# Altium schematic sheet 01_Connector.SchDoc
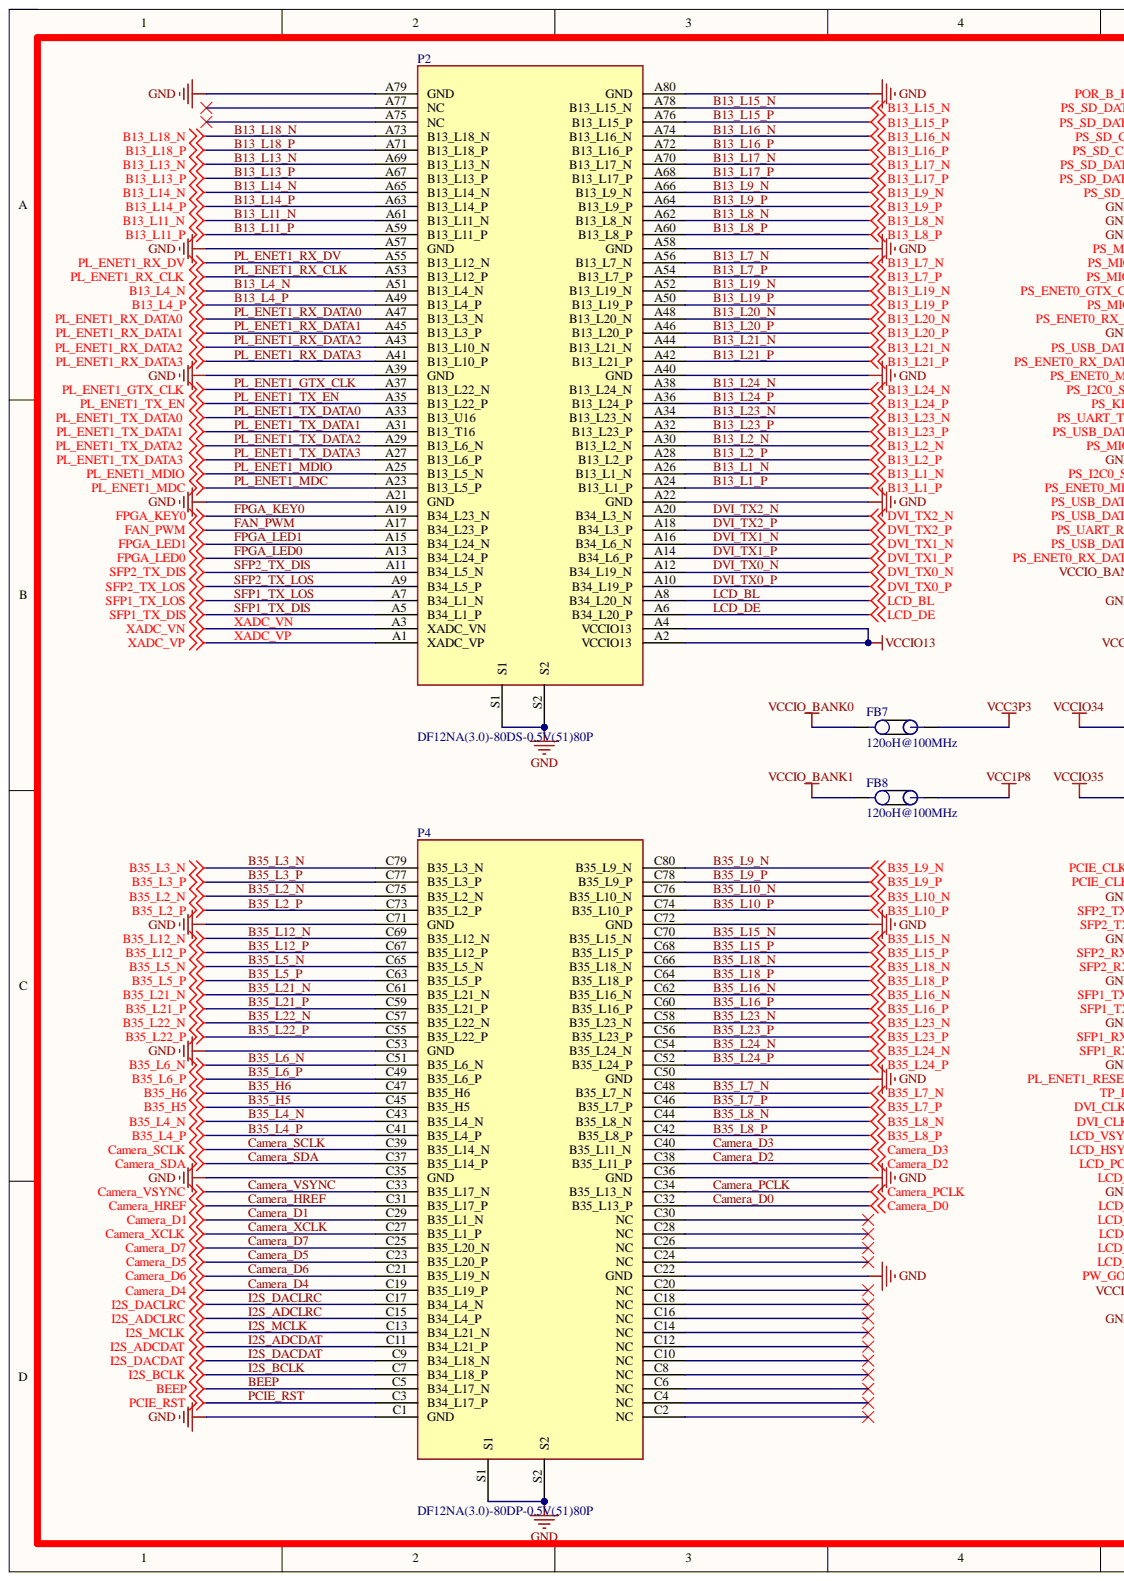
[diagram p0: 01_Connector.SchDoc, left]
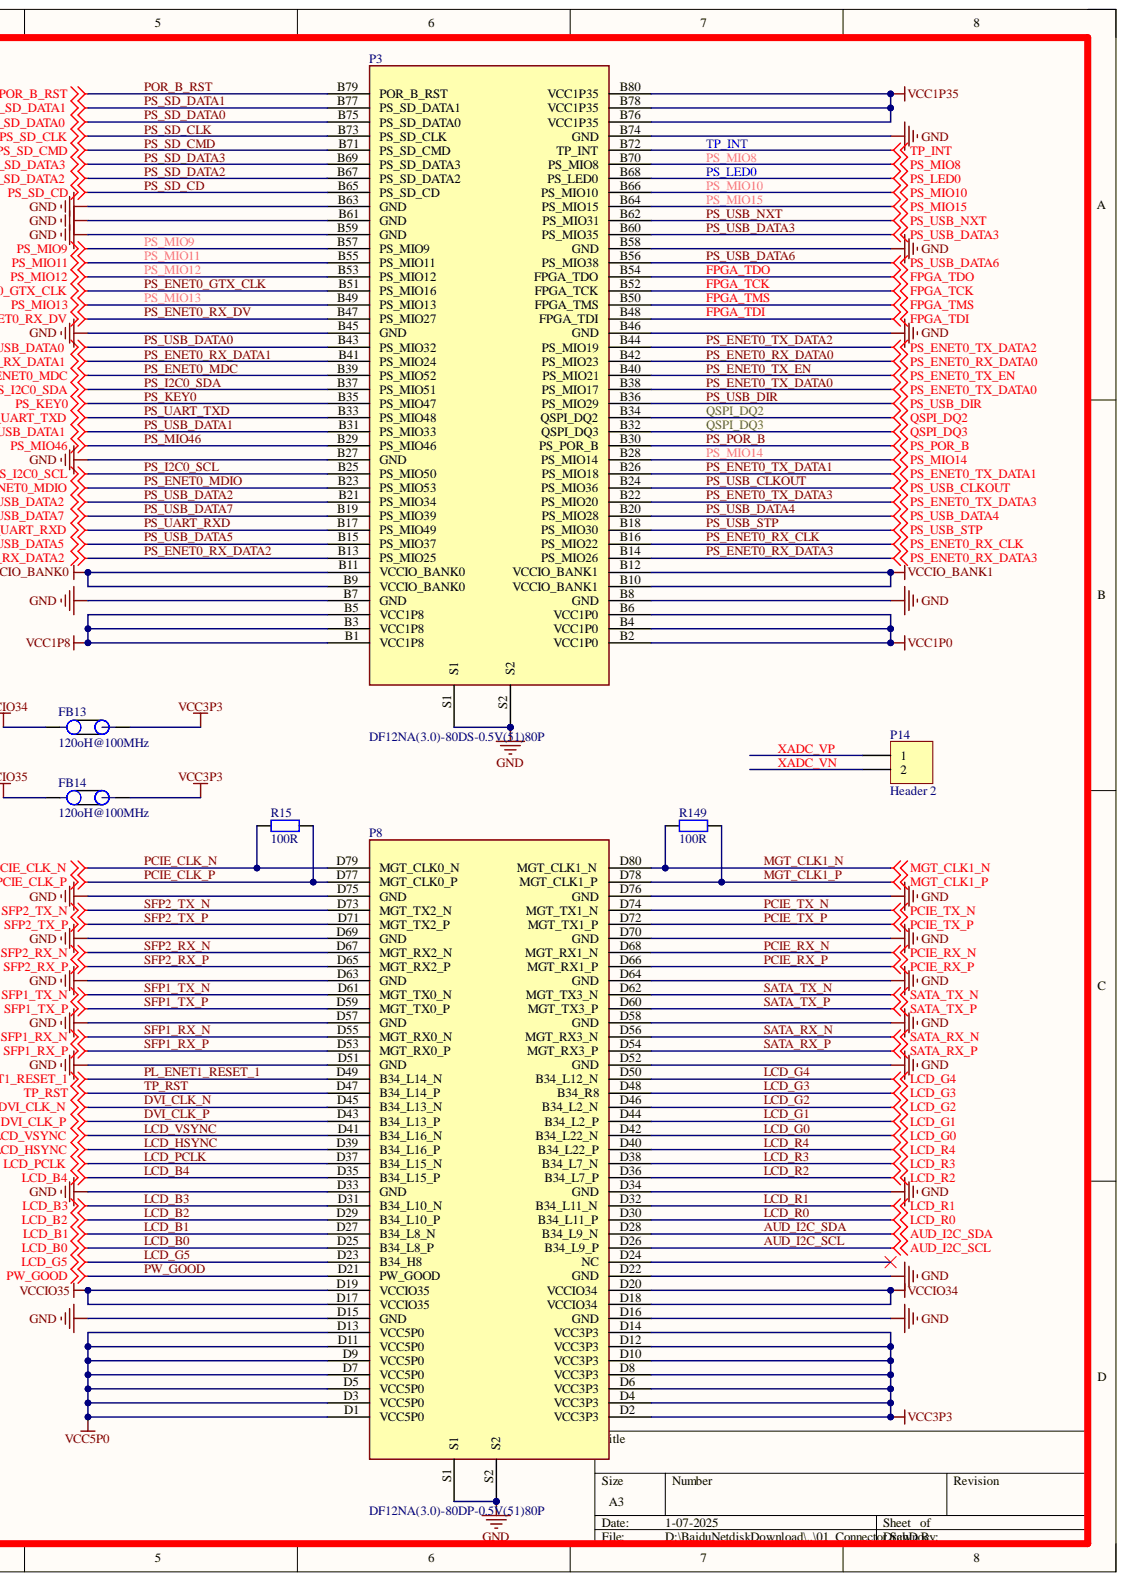
[diagram p1: 01_Connector.SchDoc, right]

## Parts
FB7  120oH@100MHz  [L0603]
FB8  120oH@100MHz  [L0603]
FB13  120oH@100MHz  [L0603]
FB14  120oH@100MHz  [L0603]
P2  DF12NA(3.0)-80DS-0.5V(51)80P  [BTB80-050-RECEPTACLE_A]
P3  DF12NA(3.0)-80DS-0.5V(51)80P  [BTB80-050-RECEPTACLE_B]
P4  DF12NA(3.0)-80DP-0.5V(51)80P  [BTB80-050-RECEPTACLE_C]
P8  DF12NA(3.0)-80DP-0.5V(51)80P  [BTB80-050-RECEPTACLE_D]
P14  Header 2  [HDR1X2]
R15  100R  [0603R]
R149  100R  [0603R]

## Nets
GND: P2.A21(GND) P2.A22(GND) P2.A39(GND) P2.A40(GND) P2.A57(GND) P2.A58(GND) P2.A79(GND) P2.A80(GND) P2.S1 P2.S2 P3.B7(GND) P3.B8(GND) P3.B27(GND) P3.B45(GND) P3.B46(GND) P3.B58(GND) P3.B59(GND) P3.B61(GND) P3.B63(GND) P3.B74(GND) P3.S1 P3.S2 P4.C1(GND) P4.C22(GND) P4.C35(GND) P4.C36(GND) P4.C50(GND) P4.C53(GND) P4.C71(GND) P4.C72(GND) P4.S1 P4.S2 P8.D15(GND) P8.D16(GND) P8.D22(GND) P8.D33(GND) P8.D34(GND) P8.D51(GND) P8.D52(GND) P8.D57(GND) P8.D58(GND) P8.D63(GND) P8.D64(GND) P8.D69(GND) P8.D70(GND) P8.D75(GND) P8.D76(GND) P8.S1 P8.S2
VCC1P0: P3.B2(VCC1P0) P3.B4(VCC1P0) P3.B6(VCC1P0)
VCC1P8: FB8.2 P3.B1(VCC1P8) P3.B3(VCC1P8) P3.B5(VCC1P8)
VCC1P35: P3.B76(VCC1P35) P3.B78(VCC1P35) P3.B80(VCC1P35)
VCC3P3: FB7.2 FB13.2 FB14.2 P8.D2(VCC3P3) P8.D4(VCC3P3) P8.D6(VCC3P3) P8.D8(VCC3P3) P8.D10(VCC3P3) P8.D12(VCC3P3) P8.D14(VCC3P3)
VCC5P0: P8.D1(VCC5P0) P8.D3(VCC5P0) P8.D5(VCC5P0) P8.D7(VCC5P0) P8.D9(VCC5P0) P8.D11(VCC5P0) P8.D13(VCC5P0)
VCCIO13: P2.A2(VCCIO13) P2.A4(VCCIO13)
VCCIO34: FB13.1 P8.D18(VCCIO34) P8.D20(VCCIO34)
VCCIO35: FB14.1 P8.D17(VCCIO35) P8.D19(VCCIO35)
VCCIO_BANK0: FB7.1 P3.B9(VCCIO_BANK0) P3.B11(VCCIO_BANK0)
VCCIO_BANK1: FB8.1 P3.B10(VCCIO_BANK1) P3.B12(VCCIO_BANK1)
AUD_I2C_SCL: P8.D26(B34_L9_P)
AUD_I2C_SDA: P8.D28(B34_L9_N)
B13_L1_N: P2.A26(B13_L1_N)
B13_L1_P: P2.A24(B13_L1_P)
B13_L2_N: P2.A30(B13_L2_N)
B13_L2_P: P2.A28(B13_L2_P)
B13_L4_N: P2.A51(B13_L4_N)
B13_L4_P: P2.A49(B13_L4_P)
B13_L7_N: P2.A56(B13_L7_N)
B13_L7_P: P2.A54(B13_L7_P)
B13_L8_N: P2.A62(B13_L8_N)
B13_L8_P: P2.A60(B13_L8_P)
B13_L9_N: P2.A66(B13_L9_N)
B13_L9_P: P2.A64(B13_L9_P)
B13_L11_N: P2.A61(B13_L11_N)
B13_L11_P: P2.A59(B13_L11_P)
B13_L13_N: P2.A69(B13_L13_N)
B13_L13_P: P2.A67(B13_L13_P)
B13_L14_N: P2.A65(B13_L14_N)
B13_L14_P: P2.A63(B13_L14_P)
B13_L15_N: P2.A78(B13_L15_N)
B13_L15_P: P2.A76(B13_L15_P)
B13_L16_N: P2.A74(B13_L16_N)
B13_L16_P: P2.A72(B13_L16_P)
B13_L17_N: P2.A70(B13_L17_N)
B13_L17_P: P2.A68(B13_L17_P)
B13_L18_N: P2.A73(B13_L18_N)
B13_L18_P: P2.A71(B13_L18_P)
B13_L19_N: P2.A52(B13_L19_N)
B13_L19_P: P2.A50(B13_L19_P)
B13_L20_N: P2.A48(B13_L20_N)
B13_L20_P: P2.A46(B13_L20_P)
B13_L21_N: P2.A44(B13_L21_N)
B13_L21_P: P2.A42(B13_L21_P)
B13_L23_N: P2.A34(B13_L23_N)
B13_L23_P: P2.A32(B13_L23_P)
B13_L24_N: P2.A38(B13_L24_N)
B13_L24_P: P2.A36(B13_L24_P)
B35_H5: P4.C45(B35_H5)
B35_H6: P4.C47(B35_H6)
B35_L2_N: P4.C75(B35_L2_N)
B35_L2_P: P4.C73(B35_L2_P)
B35_L3_N: P4.C79(B35_L3_N)
B35_L3_P: P4.C77(B35_L3_P)
B35_L4_N: P4.C43(B35_L4_N)
B35_L4_P: P4.C41(B35_L4_P)
B35_L5_N: P4.C65(B35_L5_N)
B35_L5_P: P4.C63(B35_L5_P)
B35_L6_N: P4.C51(B35_L6_N)
B35_L6_P: P4.C49(B35_L6_P)
B35_L7_N: P4.C48(B35_L7_N)
B35_L7_P: P4.C46(B35_L7_P)
B35_L8_N: P4.C44(B35_L8_N)
B35_L8_P: P4.C42(B35_L8_P)
B35_L9_N: P4.C80(B35_L9_N)
B35_L9_P: P4.C78(B35_L9_P)
B35_L10_N: P4.C76(B35_L10_N)
B35_L10_P: P4.C74(B35_L10_P)
B35_L12_N: P4.C69(B35_L12_N)
B35_L12_P: P4.C67(B35_L12_P)
B35_L15_N: P4.C70(B35_L15_N)
B35_L15_P: P4.C68(B35_L15_P)
B35_L16_N: P4.C62(B35_L16_N)
B35_L16_P: P4.C60(B35_L16_P)
B35_L18_N: P4.C66(B35_L18_N)
B35_L18_P: P4.C64(B35_L18_P)
B35_L21_N: P4.C61(B35_L21_N)
B35_L21_P: P4.C59(B35_L21_P)
B35_L22_N: P4.C57(B35_L22_N)
B35_L22_P: P4.C55(B35_L22_P)
B35_L23_N: P4.C58(B35_L23_N)
B35_L23_P: P4.C56(B35_L23_P)
B35_L24_N: P4.C54(B35_L24_N)
B35_L24_P: P4.C52(B35_L24_P)
BEEP: P4.C5(B34_L17_N)
Camera_D0: P4.C32(B35_L13_P)
Camera_D1: P4.C29(B35_L1_N)
Camera_D2: P4.C38(B35_L11_P)
Camera_D3: P4.C40(B35_L11_N)
Camera_D4: P4.C19(B35_L19_P)
Camera_D5: P4.C23(B35_L20_P)
Camera_D6: P4.C21(B35_L19_N)
Camera_D7: P4.C25(B35_L20_N)
Camera_HREF: P4.C31(B35_L17_P)
Camera_PCLK: P4.C34(B35_L13_N)
Camera_SCLK: P4.C39(B35_L14_N)
Camera_SDA: P4.C37(B35_L14_P)
Camera_VSYNC: P4.C33(B35_L17_N)
Camera_XCLK: P4.C27(B35_L1_P)
DVI_CLK_N: P8.D45(B34_L13_N)
DVI_CLK_P: P8.D43(B34_L13_P)
DVI_TX0_N: P2.A12(B34_L19_N)
DVI_TX0_P: P2.A10(B34_L19_P)
DVI_TX1_N: P2.A16(B34_L6_N)
DVI_TX1_P: P2.A14(B34_L6_P)
DVI_TX2_N: P2.A20(B34_L3_N)
DVI_TX2_P: P2.A18(B34_L3_P)
FAN_PWM: P2.A17(B34_L23_P)
FPGA_KEY0: P2.A19(B34_L23_N)
FPGA_LED0: P2.A13(B34_L24_P)
FPGA_LED1: P2.A15(B34_L24_N)
FPGA_TCK: P3.B52(FPGA_TCK)
FPGA_TDI: P3.B48(FPGA_TDI)
FPGA_TDO: P3.B54(FPGA_TDO)
FPGA_TMS: P3.B50(FPGA_TMS)
I2S_ADCDAT: P4.C11(B34_L21_P)
I2S_ADCLRC: P4.C15(B34_L4_P)
I2S_BCLK: P4.C7(B34_L18_P)
I2S_DACDAT: P4.C9(B34_L18_N)
I2S_DACLRC: P4.C17(B34_L4_N)
I2S_MCLK: P4.C13(B34_L21_N)
LCD_B0: P8.D25(B34_L8_P)
LCD_B1: P8.D27(B34_L8_N)
LCD_B2: P8.D29(B34_L10_P)
LCD_B3: P8.D31(B34_L10_N)
LCD_B4: P8.D35(B34_L15_P)
LCD_BL: P2.A8(B34_L20_N)
LCD_DE: P2.A6(B34_L20_P)
LCD_G0: P8.D42(B34_L22_N)
LCD_G1: P8.D44(B34_L2_P)
LCD_G2: P8.D46(B34_L2_N)
LCD_G3: P8.D48(B34_R8)
LCD_G4: P8.D50(B34_L12_N)
LCD_G5: P8.D23(B34_H8)
LCD_HSYNC: P8.D39(B34_L16_P)
LCD_PCLK: P8.D37(B34_L15_N)
LCD_R0: P8.D30(B34_L11_P)
LCD_R1: P8.D32(B34_L11_N)
LCD_R2: P8.D36(B34_L7_P)
LCD_R3: P8.D38(B34_L7_N)
LCD_R4: P8.D40(B34_L22_P)
LCD_VSYNC: P8.D41(B34_L16_N)
MGT_CLK1_N: P8.D80(MGT_CLK1_N) R149.2
MGT_CLK1_P: P8.D78(MGT_CLK1_P) R149.1
PCIE_CLK_N: P8.D79(MGT_CLK0_N) R15.2
PCIE_CLK_P: P8.D77(MGT_CLK0_P) R15.1
PCIE_RST: P4.C3(B34_L17_P)
PCIE_RX_N: P8.D68(MGT_RX1_N)
PCIE_RX_P: P8.D66(MGT_RX1_P)
PCIE_TX_N: P8.D74(MGT_TX1_N)
PCIE_TX_P: P8.D72(MGT_TX1_P)
PL_ENET1_GTX_CLK: P2.A37(B13_L22_N)
PL_ENET1_MDC: P2.A23(B13_L5_P)
PL_ENET1_MDIO: P2.A25(B13_L5_N)
PL_ENET1_RESET_1: P8.D49(B34_L14_N)
PL_ENET1_RX_CLK: P2.A53(B13_L12_P)
PL_ENET1_RX_DATA0: P2.A47(B13_L3_N)
PL_ENET1_RX_DATA1: P2.A45(B13_L3_P)
PL_ENET1_RX_DATA2: P2.A43(B13_L10_N)
PL_ENET1_RX_DATA3: P2.A41(B13_L10_P)
PL_ENET1_RX_DV: P2.A55(B13_L12_N)
PL_ENET1_TX_DATA0: P2.A33(B13_U16)
PL_ENET1_TX_DATA1: P2.A31(B13_T16)
PL_ENET1_TX_DATA2: P2.A29(B13_L6_N)
PL_ENET1_TX_DATA3: P2.A27(B13_L6_P)
PL_ENET1_TX_EN: P2.A35(B13_L22_P)
POR_B_RST: P3.B79(POR_B_RST)
PS_ENET0_GTX_CLK: P3.B51(PS_MIO16)
PS_ENET0_MDC: P3.B39(PS_MIO52)
PS_ENET0_MDIO: P3.B23(PS_MIO53)
PS_ENET0_RX_CLK: P3.B16(PS_MIO22)
PS_ENET0_RX_DATA0: P3.B42(PS_MIO23)
PS_ENET0_RX_DATA1: P3.B41(PS_MIO24)
PS_ENET0_RX_DATA2: P3.B13(PS_MIO25)
PS_ENET0_RX_DATA3: P3.B14(PS_MIO26)
PS_ENET0_RX_DV: P3.B47(PS_MIO27)
PS_ENET0_TX_DATA0: P3.B38(PS_MIO17)
PS_ENET0_TX_DATA1: P3.B26(PS_MIO18)
PS_ENET0_TX_DATA2: P3.B44(PS_MIO19)
PS_ENET0_TX_DATA3: P3.B22(PS_MIO20)
PS_ENET0_TX_EN: P3.B40(PS_MIO21)
PS_I2C0_SCL: P3.B25(PS_MIO50)
PS_I2C0_SDA: P3.B37(PS_MIO51)
PS_KEY0: P3.B35(PS_MIO47)
PS_LED0: P3.B68(PS_LED0)
PS_MIO8: P3.B70(PS_MIO8)
PS_MIO9: P3.B57(PS_MIO9)
PS_MIO10: P3.B66(PS_MIO10)
PS_MIO11: P3.B55(PS_MIO11)
PS_MIO12: P3.B53(PS_MIO12)
PS_MIO13: P3.B49(PS_MIO13)
PS_MIO14: P3.B28(PS_MIO14)
PS_MIO15: P3.B64(PS_MIO15)
PS_MIO46: P3.B29(PS_MIO46)
PS_POR_B: P3.B30(PS_POR_B)
PS_SD_CD: P3.B65(PS_SD_CD)
PS_SD_CLK: P3.B73(PS_SD_CLK)
PS_SD_CMD: P3.B71(PS_SD_CMD)
PS_SD_DATA0: P3.B75(PS_SD_DATA0)
PS_SD_DATA1: P3.B77(PS_SD_DATA1)
PS_SD_DATA2: P3.B67(PS_SD_DATA2)
PS_SD_DATA3: P3.B69(PS_SD_DATA3)
PS_UART_RXD: P3.B17(PS_MIO49)
PS_UART_TXD: P3.B33(PS_MIO48)
PS_USB_CLKOUT: P3.B24(PS_MIO36)
PS_USB_DATA0: P3.B43(PS_MIO32)
PS_USB_DATA1: P3.B31(PS_MIO33)
PS_USB_DATA2: P3.B21(PS_MIO34)
PS_USB_DATA3: P3.B60(PS_MIO35)
PS_USB_DATA4: P3.B20(PS_MIO28)
PS_USB_DATA5: P3.B15(PS_MIO37)
PS_USB_DATA6: P3.B56(PS_MIO38)
PS_USB_DATA7: P3.B19(PS_MIO39)
PS_USB_DIR: P3.B36(PS_MIO29)
PS_USB_NXT: P3.B62(PS_MIO31)
PS_USB_STP: P3.B18(PS_MIO30)
PW_GOOD: P8.D21(PW_GOOD)
QSPI_DQ2: P3.B34(QSPI_DQ2)
QSPI_DQ3: P3.B32(QSPI_DQ3)
SATA_RX_N: P8.D56(MGT_RX3_N)
SATA_RX_P: P8.D54(MGT_RX3_P)
SATA_TX_N: P8.D62(MGT_TX3_N)
SATA_TX_P: P8.D60(MGT_TX3_P)
SFP1_RX_N: P8.D55(MGT_RX0_N)
SFP1_RX_P: P8.D53(MGT_RX0_P)
SFP1_TX_DIS: P2.A5(B34_L1_P)
SFP1_TX_LOS: P2.A7(B34_L1_N)
SFP1_TX_N: P8.D61(MGT_TX0_N)
SFP1_TX_P: P8.D59(MGT_TX0_P)
SFP2_RX_N: P8.D67(MGT_RX2_N)
SFP2_RX_P: P8.D65(MGT_RX2_P)
SFP2_TX_DIS: P2.A11(B34_L5_N)
SFP2_TX_LOS: P2.A9(B34_L5_P)
SFP2_TX_N: P8.D73(MGT_TX2_N)
SFP2_TX_P: P8.D71(MGT_TX2_P)
TP_INT: P3.B72(TP_INT)
TP_RST: P8.D47(B34_L14_P)
XADC_VN: P2.A3(XADC_VN) P14.2
XADC_VP: P2.A1(XADC_VP) P14.1

Not connected: P2 A75(NC) A77(NC); P4 C2(NC) C4(NC) C6(NC) C8(NC) C10(NC) C12(NC) C14(NC) C16(NC) C18(NC) C20(NC) C24(NC) C26(NC) C28(NC) C30(NC); P8 D24(NC)

## Library data of the parts
FB7 FB8 FB13 FB14 = CiZhu: Component Kind: Standard; Description: CiZhu; Library Name: zynq.SCHLIB; Value: 120oH@100MHz
P2 = BTB80-050-RECEPTACLE_A: Component Kind: Standard; Description: DF12NA(3.0)-80DS-0.5V(51)80P; Library Name: ACZ7015-CB-RevA.SCHLIB
P3 = BTB80-050-RECEPTACLE_B: Component Kind: Standard; Description: DF12NA(3.0)-80DS-0.5V(51)80P; Library Name: ACZ7015-CB-RevA.SCHLIB
P4 = BTB80-050-RECEPTACLE_C: Component Kind: Standard; Description: DF12NA(3.0)-80DP-0.5V(51)80P; Library Name: ACZ7015-CB-RevA.SCHLIB
P8 = BTB80-050-RECEPTACLE_D: Component Kind: Standard; Description: DF12NA(3.0)-80DP-0.5V(51)80P; Library Name: ACZ7015-CB-RevA.SCHLIB
P14 = Header 2: Header, 2-Pin; Component Kind: Standard; LatestRevisionDate: 17-Jul-2002; LatestRevisionNote: Re-released for DXP Platform.; Library Name: Miscellaneous Connectors.IntLib; Signal Integrity: Connector; Publisher: Altium Limited
R15 R149 = Res2: Resistor; Component Kind: Standard; LatestRevisionDate: 17-Jul-2002; LatestRevisionNote: Re-released for DXP Platform.; PackageReference: AXIAL-0.4; Published: 8-Jun-2000; Value: 100R; Library Name: 元件封装库.pcblib; Publisher: Altium Limited
